# Altium schematic sheet PowerStage.SchDoc
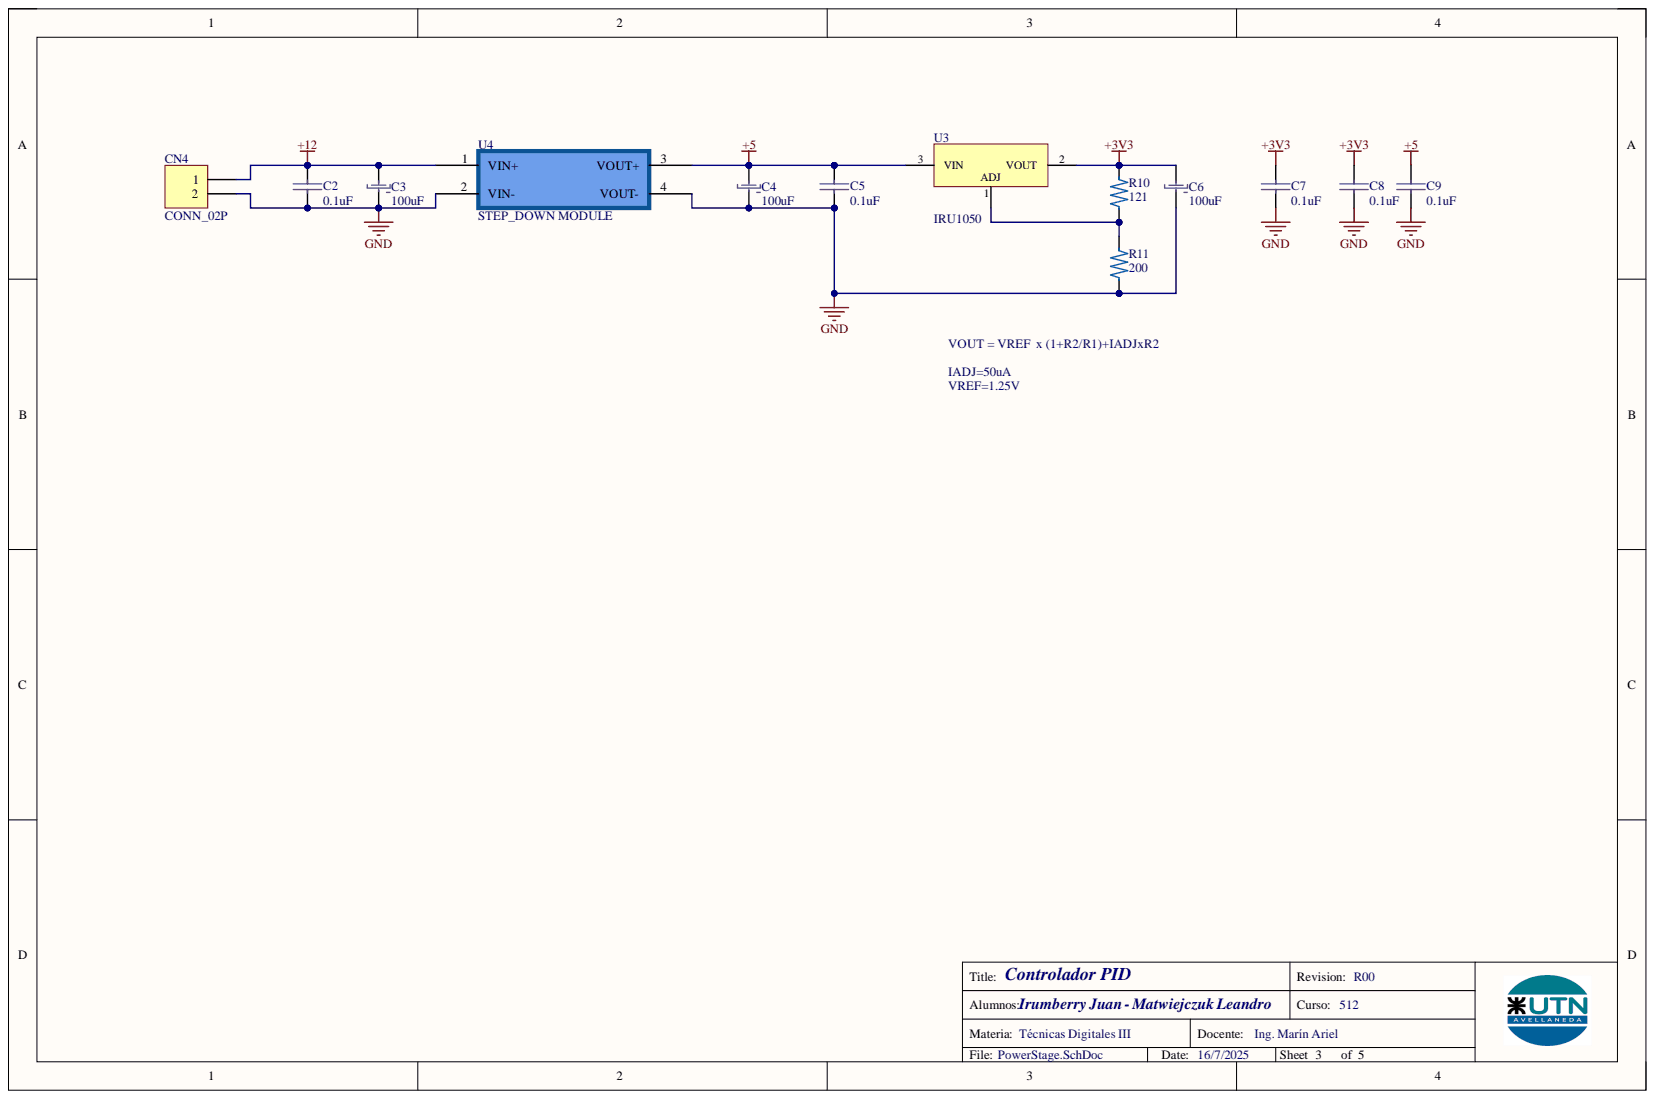
[diagram p0: PowerStage.SchDoc]

## Parts
C2  0.1uF  [CAP_Axial_0.2mm]
C3  100uF  [C_RAD_11MM]
C4  100uF  [C_RAD_5mm]
C5  0.1uF  [CAP_Axial_0.2mm]
C6  100uF  [C_RAD_5mm]
C7  0.1uF  [CAP_Axial_0.2mm]
C8  0.1uF  [CAP_Axial_0.2mm]
C9  0.1uF  [CAP_Axial_0.2mm]
CN4  CONN_02P  [TERMINAL_BLOCK_2_PIN]  (value not drawn)
R10  121  [RES_AXIAL_3.2_6MM]
R11  200  [RES_AXIAL_3.2_6MM]
U3  IRU1050  [IRU1050]
U4  STEP_DOWN MODULE  [STEP_DOWN_MODULE]

## Nets
+3V3: C6.1 C7.1 C8.1 R10.2 U3.2
+5: C4.1 C5.1 C9.1 U3.3 U4.3(VOUT+)
+12: C2.1 C3.1 CN4.1 U4.1(VIN+)
GND: C2.2 C3.2 C4.2 C5.2 C6.2 C7.2 C8.2 C9.2 CN4.2 R11.1 U4.2(VIN-) U4.4(VOUT-)
NetR10_1: R10.1 R11.2 U3.1

## Library data of the parts
C2 C5 C7-C9 = CAP_NP: Non-Polarized Capacitor; Component Kind: Standard; Library Name: ProyectoTD3.SchLib
C3 C4 C6 = Cap_Pol: Polarized Capacitor; Component Kind: Standard; Library Name: ProyectoTD3.SchLib
CN4 = CONN_02P: Connector Generic 2 Vias; Component Kind: Standard; Library Name: ProyectoTD3.SchLib
R10 R11 = RES: Through-hole Resistor; Component Kind: Standard; Library Name: ProyectoTD3.SchLib
U3 = IRU1050: 5A  LOW DROPOUT POSITIVEADJUSTABLE REGULATOR; Component Kind: Standard; Library Name: ProyectoTD3.SchLib
U4 = STEP_DOWN_MODULE: Component Kind: Standard; Library Name: ProyectoTD3.SchLib
